# Altium schematic sheet IO.SchDoc(IO)
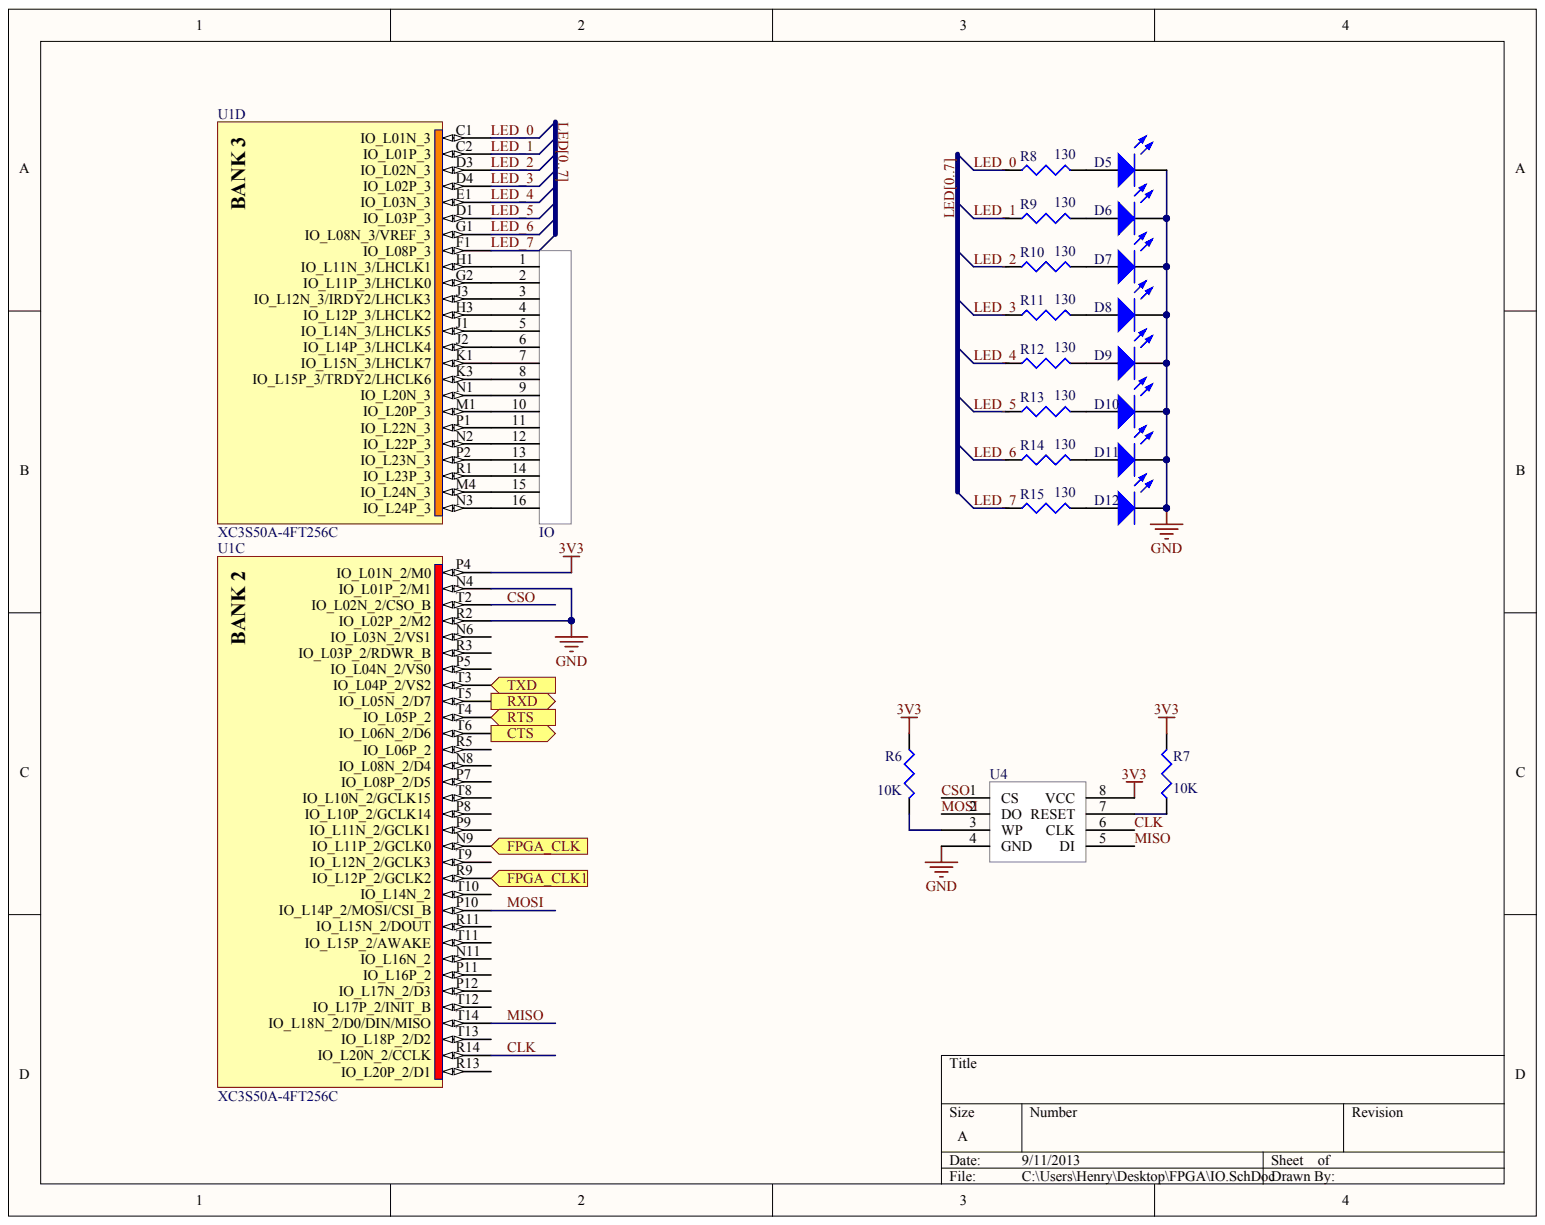
[diagram p0: IO.SchDoc]

## Parts
D5  LED3  (value not drawn)
D6  LED3  (value not drawn)
D7  LED3  (value not drawn)
D8  LED3  (value not drawn)
D9  LED3  (value not drawn)
D10  LED3  (value not drawn)
D11  LED3  (value not drawn)
D12  LED3  (value not drawn)
R6  value 10K  (hidden comment: Res3)
R7  value 10K  (hidden comment: Res3)
R8  value 130  (hidden comment: Res3)
R9  value 130  (hidden comment: Res3)
R10  value 130  (hidden comment: Res3)
R11  value 130  (hidden comment: Res3)
R12  value 130  (hidden comment: Res3)
R13  value 130  (hidden comment: Res3)
R14  value 130  (hidden comment: Res3)
R15  value 130  (hidden comment: Res3)
U1  XC3S50A-4FT256C  (parts C,D)
U4  W25Q80  (value not drawn)
  IO

## Ports
CTS, FPGA_CLK, FPGA_CLK1, RTS, RXD, TXD

## Nets
3V3: R6.1 R7.2 U1.P4(IO_L01N_2/M0) U4.8(VCC)
GND: D5.2 D6.2 D7.2 D8.2 D9.2 D10.2 D11.2 D12.2 U1.N4(IO_L01P_2/M1) U1.R2(IO_L02P_2/M2) U4.4(GND)
Net_1: U1.H1(IO_L11N_3/LHCLK1) .1
Net_2: U1.G2(IO_L11P_3/LHCLK0) .2
Net_3: U1.J3(IO_L12N_3/IRDY2/LHCLK3) .3
Net_4: U1.H3(IO_L12P_3/LHCLK2) .4
Net_5: U1.J1(IO_L14N_3/LHCLK5) .5
Net_6: U1.J2(IO_L14P_3/LHCLK4) .6
Net_7: U1.K1(IO_L15N_3/LHCLK7) .7
Net_8: U1.K3(IO_L15P_3/TRDY2/LHCLK6) .8
Net_9: U1.N1(IO_L20N_3) .9
Net_10: U1.M1(IO_L20P_3) .10
Net_11: U1.P1(IO_L22N_3) .11
Net_12: U1.N2(IO_L22P_3) .12
Net_13: U1.P2(IO_L23N_3) .13
Net_14: U1.R1(IO_L23P_3) .14
Net_15: U1.M4(IO_L24N_3) .15
Net_16: U1.N3(IO_L24P_3) .16
CLK: U1.R14(IO_L20N_2/CCLK) U4.6(CLK)
CSO: U1.T2(IO_L02N_2/CSO_B) U4.1(CS)
CTS: U1.T6(IO_L06N_2/D6) ; port CTS
FPGA_CLK: U1.N9(IO_L11P_2/GCLK0) ; port FPGA_CLK
FPGA_CLK1: U1.R9(IO_L12P_2/GCLK2) ; port FPGA_CLK1
LED_0: R8.1 U1.C1(IO_L01N_3)
LED_1: R9.1 U1.C2(IO_L01P_3)
LED_2: R10.1 U1.D3(IO_L02N_3)
LED_3: R11.1 U1.D4(IO_L02P_3)
LED_4: R12.1 U1.E1(IO_L03N_3)
LED_5: R13.1 U1.D1(IO_L03P_3)
LED_6: R14.1 U1.G1(IO_L08N_3/VREF_3)
LED_7: R15.1 U1.F1(IO_L08P_3)
MISO: U1.T14(IO_L18N_2/D0/DIN/MISO) U4.5(DI)
MOSI: U1.P10(IO_L14P_2/MOSI/CSI_B) U4.2(DO)
RTS: U1.T4(IO_L05P_2) ; port RTS
RXD: U1.T5(IO_L05N_2/D7) ; port RXD
TXD: U1.T3(IO_L04P_2/VS2) ; port TXD
NetD5_1: D5.1 R8.2
NetD6_1: D6.1 R9.2
NetD7_1: D7.1 R10.2
NetD8_1: D8.1 R11.2
NetD9_1: D9.1 R12.2
NetD10_1: D10.1 R13.2
NetD11_1: D11.1 R14.2
NetD12_1: D12.1 R15.2
NetR6_2: R6.2 U4.3(WP)
NetR7_1: R7.1 U4.7(RESET)
LED0:  [also labelled LED]
LED1:  [also labelled LED]
LED2:  [also labelled LED]
LED3:  [also labelled LED]
LED4:  [also labelled LED]
LED5:  [also labelled LED]
LED6:  [also labelled LED]
LED7:  [also labelled LED]

Not connected: U1 N6(IO_L03N_2/VS1) N8(IO_L08N_2/D4) N11(IO_L16N_2) P5(IO_L04N_2/VS0) P7(IO_L08P_2/D5) P8(IO_L10P_2/GCLK14) P9(IO_L11N_2/GCLK1) P11(IO_L16P_2) P12(IO_L17N_2/D3) R3(IO_L03P_2/RDWR_B) R5(IO_L06P_2) R11(IO_L15N_2/DOUT) R13(IO_L20P_2/D1) T8(IO_L10N_2/GCLK15) T9(IO_L12N_2/GCLK3) T10(IO_L14N_2) T11(IO_L15P_2/AWAKE) T12(IO_L17P_2/INIT_B) T13(IO_L18P_2/D2)

## Library data of the parts
D5-D12 R6-R15 U1 U4  = (no symbol name)
  D5-D12: Published: 8-Jun-2000; LatestRevisionDate: 17-Dec-2003; LatestRevisionNote: PCB Footprint replaced.; DatasheetVersion: 16-Apr-2002; PackageVersion: 16-Apr-2002; PackageReference: 3.5X2.8X1.9; PackageDescription: SM LED; 2 C-Bend Leads; Body 3.5 x 2.8 x 1.9 mm, inc leads (LxWxH typ)
  R6-R15: Published: 8-Jun-2000; LatestRevisionDate: 29-May-2009; LatestRevisionNote: IPC-7351 Footprint Added.; PackageReference: J1-0603; PackageDescription: Chip Resistor
  U1: Published: 12-Dec-2006; LatestRevisionDate: 24-Apr-2009; LatestRevisionNote: Updated to datasheet version 1.8 Mar-2009; DatasheetVersion: ver1.8, Mar-2009; PackageVersion: ver1.3, Oct-2007; PackageReference: FT256; PackageDescription: 256-Ball Fine Pitch Thin Ball Grid Array 1.00 mm Pitch (FT256); Supplier 1: Digi-Key; Supplier Part Number 1: XC3S50A-4FT256C-ND; Code_JEDEC: MS-034-AAF-1*; ComponentLink1Description: Spartan-3A Datasheet; ComponentLink1URL: http://www.xilinx.com/support/documentation/data_sheets/ds529.pdf; ComponentLink2Description: FT256 Package Drawing; ComponentLink2URL: http://www.xilinx.com/support/documentation/package_specs/ft256.pdf; ComponentLink3Description: Spartan-3A Documents; ComponentLink3URL: http://www.xilinx.com/support/documentation/spartan-3a.htm; ComponentLink4Description: Xilinx.com Home; ComponentLink4URL: http://www.xilinx.com
  D5-D12 R6-R15 U1: Publisher: Altium Limited
